# Altium schematic sheet RF19_Sensor_CAN_Module_Power.SchDoc
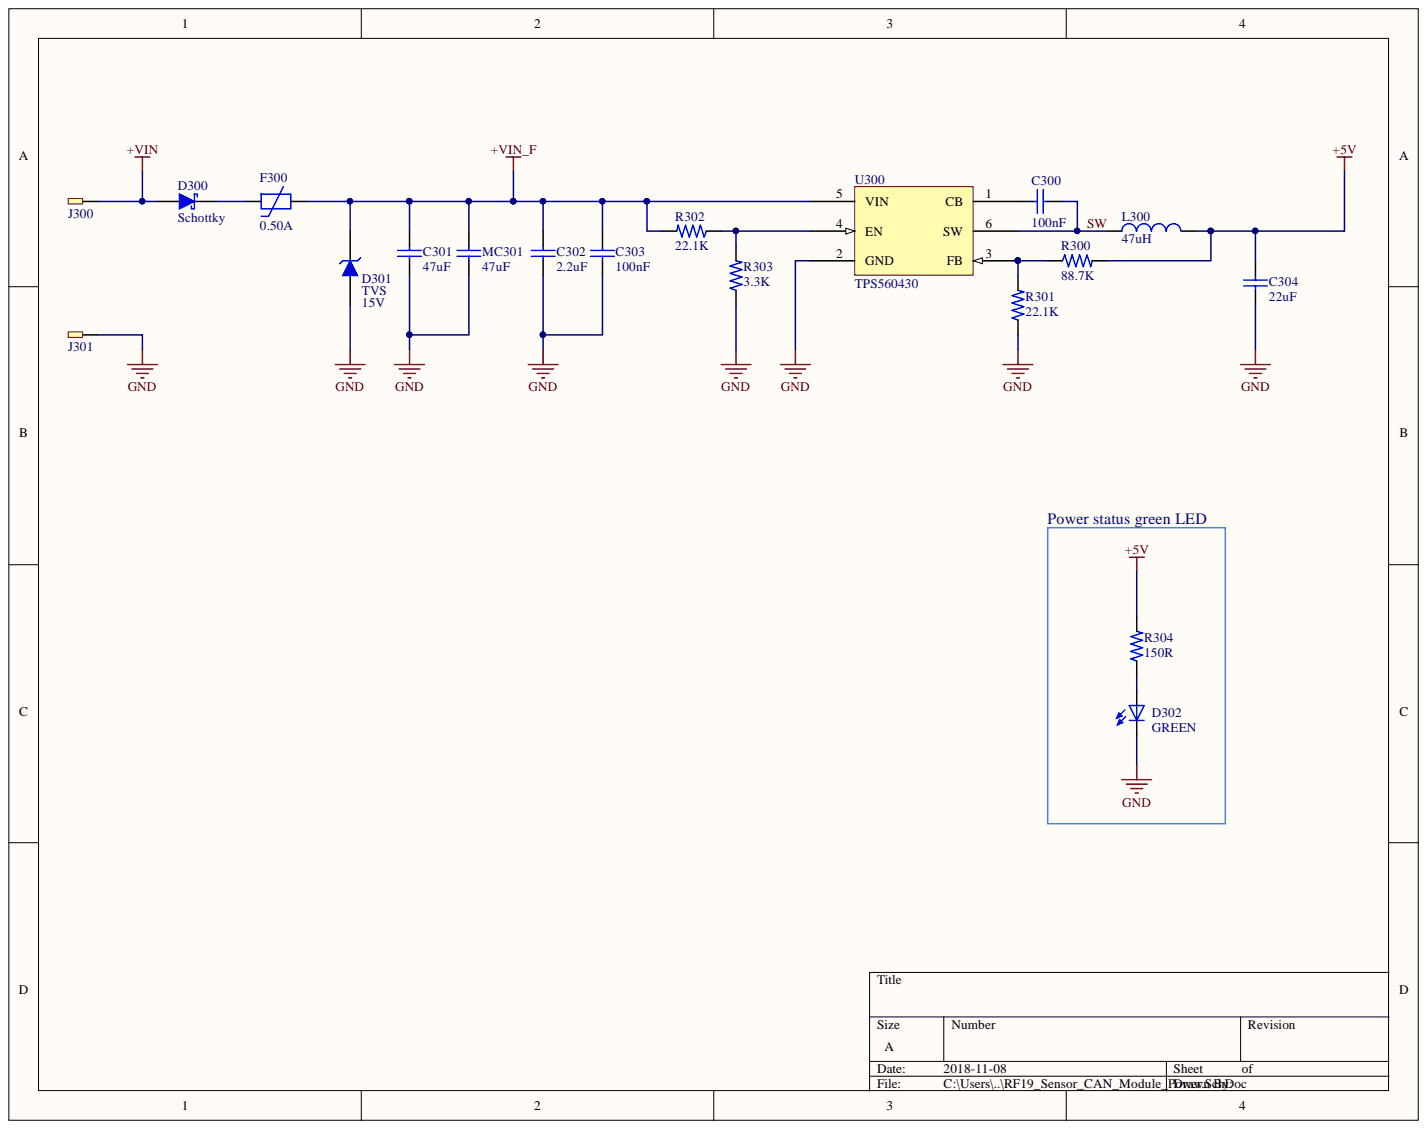
[diagram p0: RF19_Sensor_CAN_Module_Power.SchDoc]

## Parts
C300  value 100nF  [C0402]  (hidden comment: CERAMIC CAP)
C301  value 47uF  [C1206]  (hidden comment: CERAMIC CAP)
C302  value 2.2uF  [C0603]  (hidden comment: CERAMIC CAP)
C303  value 100nF  [C0603]  (hidden comment: CERAMIC CAP)
C304  value 22uF  [C0805]  (hidden comment: CERAMIC CAP)
D300  Schottky  [SOD-323W]
D301  TVS  value 15V  [DO-214AC]
D302  GREEN  [0603 LED]
F300  0.50A  [PTC 0805]
J300  BREAKOUT  [BREAKOOUT PAD]  (value not drawn)
J301  BREAKOUT  [BREAKOOUT PAD]  (value not drawn)
L300  value 47uH  [SRN4018TA]  (hidden comment: Inductor)
MC301  value 47uF  [C1206]  (hidden comment: CERAMIC CAP)
R300  value 88.7K  [R0402]  (hidden comment: RESISTOR)
R301  value 22.1K  [R0402]  (hidden comment: RESISTOR)
R302  value 22.1K  [R0402]  (hidden comment: RESISTOR)
R303  value 3.3K  [R0402]  (hidden comment: RESISTOR)
R304  value 150R  [R0402]  (hidden comment: RESISTOR)
U300  TPS560430  [TI_SOP8_R-PDSO-G6]

## Nets
+5V: C304.1 L300.2 R300.2 R304.2
+VIN: D300.A J300.1
+VIN_F: C301.1 C302.1 C303.1 D301.C F300.2 MC301.1 R302.2 U300.5(VIN)
GND: C301.2 C302.2 C303.2 C304.2 D301.A D302.C J301.1 MC301.2 R301.1 R303.1 U300.2(GND)
SW: C300.1 L300.1 U300.6(SW)
NetC300_2: C300.2 U300.1(CB)
NetD300_C: D300.C F300.1
NetD302_A: D302.A R304.1
NetR300_1: R300.1 R301.2 U300.3(FB)
NetR302_1: R302.1 R303.2 U300.4(EN)

## Library data of the parts
C300 C302-C304 = CERAMIC CAP: Ceramic Capacitor; Component Kind: Standard; Library Name: Custom.SchLib
C301 MC301 = CAP CERAMIC: Ceramic capacitor; Component Kind: Standard; Library Name: Custom.SchLib
D300 = DIODE SCHOTTKY: High speed Schottky Diode; Component Kind: Standard; Library Name: Custom.SchLib
D301 = DIODE TVS: TVS protection diode; Power: 1W; Component Kind: Standard; Library Name: Custom.SchLib
D302 = LED: Standard LED, 5mm or 0603 SMD; Component Kind: Standard; Library Name: Custom.SchLib
F300 = PTC: PTC RESETTBLE 0.50A 9V 0805; Component Kind: Standard; Library Name: Custom.SchLib
J300 J301 = BREAKOUT: Breakout pad for later extension; Component Kind: Standard; Library Name: Custom.SchLib
L300 = Inductor: Code_IEC: 1005; Code_JEITA: 0402; Component Kind: Standard; Description: Inductor; LatestRevisionDate: 29-May-2009; LatestRevisionNote: IPC-7351 Footprint Added.; Library Name: Miscellaneous Devices.IntLib; PackageDescription: Chip Inductor; PackageReference: 0402-A; Published: 8-Jun-2000; Signal Integrity: Inductor; Simulation: INDUCTOR; Publisher: Altium Limited
R300-R304 = RESISTOR: Component Kind: Standard; Description: Resistor; Library Name: Custom.SchLib
U300 = TPS560430: Component Kind: Standard; Library Name: Custom.SchLib
